# Altium schematic sheet Schematic.SchDoc
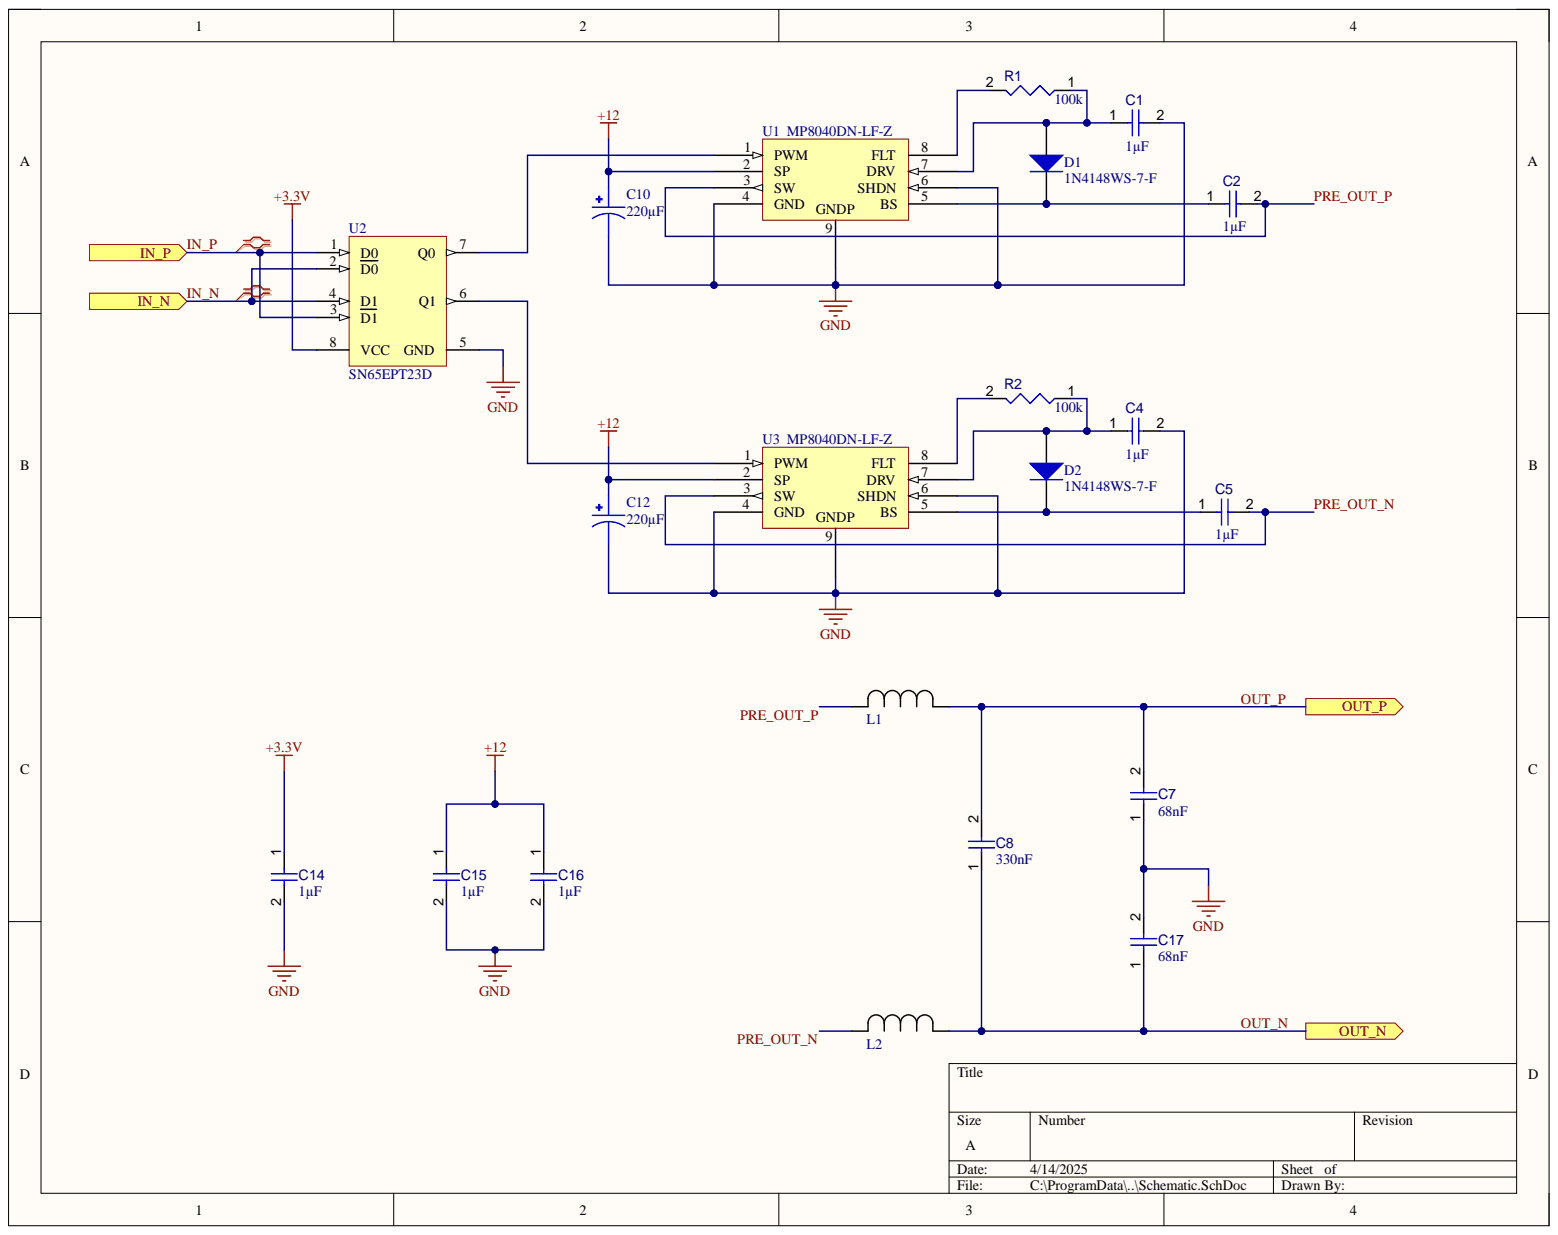
[diagram p0: Schematic.SchDoc]

## Parts
C1  value 1µF  [CAPC2013X135X45NL10T25]  (hidden comment: Capacitor 1 uF +/- 10% 50 V 0805)
C2  value 1µF  [CAPC2013X135X45NL10T25]  (hidden comment: Capacitor 1 uF +/- 10% 50 V 0805)
C4  value 1µF  [CAPC2013X135X45NL10T25]  (hidden comment: Capacitor 1 uF +/- 10% 50 V 0805)
C5  value 1µF  [CAPC2013X135X45NL10T25]  (hidden comment: Capacitor 1 uF +/- 10% 50 V 0805)
C7  value 68nF  [FP-C0805C-DN-IPC_A]  (hidden comment: C0805C683K1RACTU)
C8  value 330nF  [CAPC2012X14M]  (hidden comment: C2012X7R1H334K125AA)
C10  220µF  [CAPAE1030X1080N_UWT1H221MNL1GS]
C12  220µF  [CAPAE1030X1080N_UWT1H221MNL1GS]
C14  value 1µF  [CAPC2013X135X45NL10T25]  (hidden comment: Capacitor 1 uF +/- 10% 50 V 0805)
C15  value 1µF  [CAPC2013X135X45NL10T25]  (hidden comment: Capacitor 1 uF +/- 10% 50 V 0805)
C16  value 1µF  [CAPC2013X135X45NL10T25]  (hidden comment: Capacitor 1 uF +/- 10% 50 V 0805)
C17  value 68nF  [FP-C0805C-DN-IPC_A]  (hidden comment: C0805C683K1RACTU)
D1  1N4148WS-7-F  [DIOD-SOD323-2-A_V]
D2  1N4148WS-7-F  [DIOD-SOD323-2-A_V]
L1  PQ2614BHA-220K  [IND_PQ2614_BRN]  (value not drawn)
L2  PQ2614BHA-220K  [IND_PQ2614_BRN]  (value not drawn)
R1  value 100k  [RESC0402(1005)_L]  (hidden comment: 100KR2F)
R2  value 100k  [RESC0402(1005)_L]  (hidden comment: 100KR2F)
U1  MP8040DN-LF-Z  [SOIC127P600X130_HS-9M]
U2  SN65EPT23D  [D0008A_L]
U3  MP8040DN-LF-Z  [SOIC127P600X130_HS-9M]

## Ports
IN_N, IN_P, OUT_N, OUT_P

## Nets
+3.3V: C14.1 U2.8(VCC)
+12: C10.1 C12.1 C15.1 C16.1 U1.2(SP) U3.2(SP)
GND: C1.2 C4.2 C7.1 C10.2 C12.2 C14.2 C15.2 C16.2 C17.2 U1.4(GND) U1.6(SHDN) U1.9 U2.5(GND) U3.4(GND) U3.6(SHDN) U3.9
IN_N: U2.2(D0) U2.4(D1) ; port IN_N
IN_P: U2.1(D0) U2.3(D1) ; port IN_P
OUT_N: C8.1 C17.1 L2.1 ; port OUT_N
OUT_P: C7.2 C8.2 L1.1 ; port OUT_P
PRE_OUT_N: C5.2 L2.2 U3.3(SW)
PRE_OUT_P: C2.2 L1.2 U1.3(SW)
NetC1_1: C1.1 D1.1 R1.1 U1.7(DRV)
NetC2_1: C2.1 D1.2 U1.5(BS)
NetC4_1: C4.1 D2.1 R2.1 U3.7(DRV)
NetC5_1: C5.1 D2.2 U3.5(BS)
NetR1_2: R1.2 U1.8(FLT)
NetR2_2: R2.2 U3.8(FLT)
NetU1_1: U1.1(PWM) U2.7(Q0)
NetU2_6: U2.6(Q1) U3.1(PWM)

## Library data of the parts
C1 C2 C4 C5 C14-C16 = CMP-001-00007-6: Chip Capacitor, 1 uF, +/- 10%, 50 V, 0805 (2012 Metric); Capacitor Type: Ceramic; Voltage Rating: 50V; Case/Package: 0805; Component Kind: Standard; Max Operating Temperature: 125°C; Min Operating Temperature: -55°C; Mounting Technology: SM; Pins: 2; RoHS Compliant: True; Tolerance: 10%; Library Name: Ethan Labelson
C7 C17 = CMP-001-00086-1: Ceramic Capacitor, Multilayer, Ceramic, 100V, 10% +Tol, 10% -Tol, X7R, 15% TC, 0.068uF, Surface Mount, 0805; Capacitor Type: Ceramic; Case/Package: 0805; Component Kind: Standard; Max Operating Temperature: 125°C; Min Operating Temperature: -55°C; Mounting Technology: Surface Mount; Pins: 2; RoHS Compliant: Yes; Library Name: Ethan Labelson
C8 = CMP-001-00084-2: Ceramic Capacitor, Multilayer, Ceramic, 50V, 10% +Tol, 10% -Tol, X7R, 15% TC, 0.33uF, Surface Mount, 0805; Capacitor Type: Ceramic; Case/Package: 0805; Component Kind: Standard; Max Operating Temperature: 125°C; Min Operating Temperature: -55°C; Mounting Technology: Surface Mount; Pins: 2; RoHS Compliant: Yes; Library Name: Ethan Labelson
C10 C12 = CMP-017-000000-1: 220ÂµF 50V Aluminum Electrolytic Capacitors Radial, Can - SMD 1000 Hrs @ 105Â°C; MF: Nichicon; MP: UWT1H221MNL1GS; Availability: Unavailable; Component Kind: Standard; Component Type: Standard; Design Item ID: UWT1H221MNL1GS; Package: Radial Nichicon; Pin Count: 2; Price: None; SnapEDA_Link: https://www.snapeda.com/parts/UWT1H221MNL1GS/Nichicon/view-part/566503/?ref=snap; Source: SnapEDAsch.SchLib; Library Name: Ethan Labelson
D1 D2 = CMP-004-00002-2: Fast Switching Diode, 75 V, 0.3 A, -65 to 150 degC, 2-Pin SOD323, RoHS, Tape and Reel; Manufacturer: Diodes Inc; Elements: 1; Forward Current: 300mA; Forward Voltage: 1.25V; Max Surge Current: 2A; Peak Reverse Current: 1uA; Recovery Time: 4ns; Case/Package: SOD; Component Kind: Standard; Datasheet URL: http://www.diodes.com/datasheets/ds30097.pdf; Datasheet Version: Rev. 25-2, 03/2016; Manufacturer URL: http://www.diodes.com/; Max Operating Temperature: 150°C; Min Operating Temperature: -65°C; Mounting Technology: SM; Package Description: 2-Pin Small Outline Diode, Body 1.7 x 1.3 mm; Package Quantity: 3000; Package Reference: SOD323-2; Packaging: TapeandReel; Pins: 2; RoHS Compliant: True; Library Name: Ethan Labelson
L1 L2 = CMP-006-00021-1: No Description Available; Mfr_Name: Bourns Electronics; Type: Fixed Inductors; Component Kind: Standard; Design Item ID: PQ2614BHA-220K; Source: PQ2614BHA-220K.SchLib; Library Name: Ethan Labelson
R1 R2 = CMP-009-00064-2: 100K 0.063W 1% 0402 (1005 Metric)  SMD; Power: 63mW; Case/Package: 0402; Component Kind: Standard; Mounting Technology: SMT; Pins: 2; Tolerance: 1%; Library Name: Ethan Labelson
U1 U3 = CMP-00024-00006-1: Half Bridge Based Peripheral Driver, 9A, PDSO8; Built-in Protections: Over Current,Under Voltage,THERMAL; Nominal Supply Voltage: 12V; Case/Package: HLSOP; Component Kind: Standard; Height - Seated (Max): 1.676mm; Interface IC Type: HALF BRIDGE BASED PERIPHERAL DRIVER; JESD-30 Code: R-PDSO-G8; Max Operating Temperature: 85°C; Min Operating Temperature: -40°C; Pins: 8; RoHS Compliant: Yes; Library Name: Ethan Labelson
U2 = CMP-016-00010-1: Low Skew Clock Driver, 65EP Series, 2 True Output(s), 0 Inverted Output(s), ECL, PDSO8; Input Conditioning: DIFFERENTIAL; Max Supply Voltage: 3.6V; Min Supply Voltage: 3V; Nominal Supply Voltage: 3.3V; Case/Package: SOP; Component Kind: Standard; Height - Seated (Max): 1.75mm; JESD-30 Code: R-PDSO-G8; JESD-609 Code: e4; Logic Type: LOW SKEW CLOCK DRIVER; Max Operating Temperature: 85°C; Min Operating Temperature: -40°C; Pins: 8; RoHS Compliant: Yes; Library Name: Ethan Labelson
